# Altium schematic sheet encendido.SchDoc
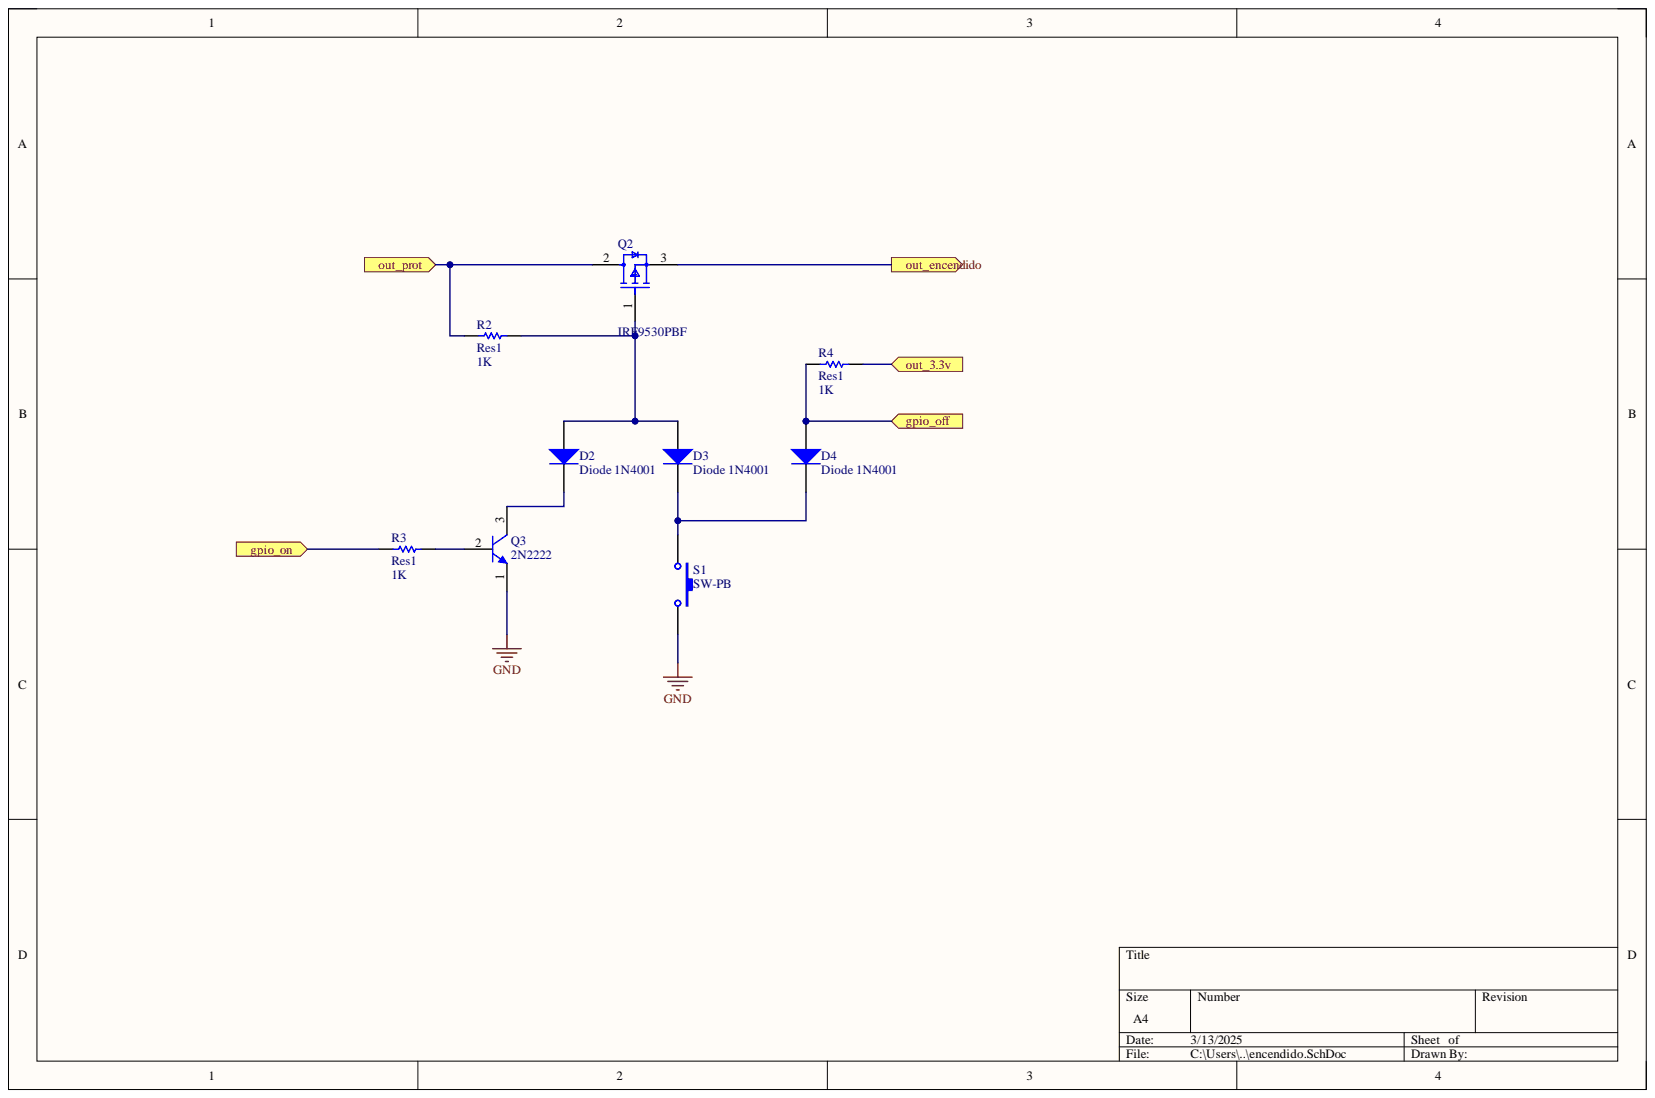
[diagram p0: encendido.SchDoc]

## Parts
D2  Diode 1N4001  [DO-41]
D3  Diode 1N4001  [DO-41]
D4  Diode 1N4001  [DO-41]
Q2  IRF9530PBF  [FP-X15-0364-MFG]
Q3  2N2222  [MLTC-TO-18-3]
R2  Res1  value 1K  [AXIAL-0.3]
R3  Res1  value 1K  [AXIAL-0.3]
R4  Res1  value 1K  [AXIAL-0.3]
S1  SW-PB  [SPST-2]

## Ports
gpio_off, gpio_on, out_3.3v, out_encendido, out_prot

## Nets
GND: Q3.1 S1.2
gpio_off: D4.1 R4.1 ; port gpio_off
gpio_on: R3.1 ; port gpio_on
out_3.3v: R4.2 ; port out_3.3v
out_encendido: Q2.3 ; port out_encendido
out_prot: Q2.2 R2.1 ; port out_prot
NetD2_1: D2.1 D3.1 Q2.1 R2.2
NetD2_2: D2.2 Q3.3
NetD3_2: D3.2 D4.2 S1.1
NetQ3_2: Q3.2 R3.2

## Library data of the parts
D2-D4 = Diode 1N4001: 1 Amp General Purpose Rectifier; Code_JEDEC: DO-204-AL; Component Kind: Standard; ComponentLink1Description: Manufacturer Link; ComponentLink1URL: http://www.fairchildsemi.com/; ComponentLink2Description: Datasheet; ComponentLink2URL: http://www.fairchildsemi.com/ds/1N%2F1N4001.pdf; DatasheetVersion: 1998; LatestRevisionDate: 17-Jul-2002; LatestRevisionNote: Re-released for DXP Platform.; Library Name: Miscellaneous Devices.IntLib; PackageDescription: Diode, Axial; Body 5.2 x 2.7 mm (LxDia. max), Lead Dia. 0.86 mm (max); PackageReference: DO-41; PackageVersion: Aug-1999; Published: 8-Jun-2000; Signal Integrity: 1N4001; Simulation: 1N4001; Publisher: Altium Limited
Q2 = CMP-02550-000233-1: MOSFET P-CH 100V 12A TO-220AB; Current Rating: -12A; Drain to Source Breakdown Voltage: -100V; Drain to Source Resistance: 300mR; Element Configuration: Single; Fall Time: 39ns; Gate to Source Voltage (Vgs): 20V; Input Capacitance: 860pF; Max Power Dissipation: 88W; Number of Channels: 1; Polarity: P-CHANNEL; Polarization: P; Recovery Time: 240ns; Rise Time: 52ns; Threshold Voltage: -4V; Turn-Off Delay Time: 31ns; Turn-On Delay Time: 12ns; Case/Package: TO-220AB; Component Kind: Standard; Continuous Drain Current (ID): 12A; Drain to Source Voltage (Vdss): 100V; Drain-source On Resistance-Max: 300mR; Height: 9.01mm; Lead Free: Lead Free; Length: 10.41mm; Library Name: Altium Content Vault; Max Operating Temperature: 175°C; Min Operating Temperature: -55°C; Mount: Through Hole; Number of Elements: 1; Number of Pins: 3; On-State Resistance: 300mR; Package Quantity: 50; Packaging: Rail/Tube; Power Dissipation: 88W; Radiation Hardening: No; Rds On Max: 300mR; RoHS Compliant: Yes; Series: -; Voltage Rating (DC): -100V; Weight: 0.211644oz; Width: 4.7mm
Q3 = CMP-2000-05237-1: Low Power Bipolar NPN Transistor, 30 V, -65 to 200 degC, 3-Pin TO-18, RoHS, Bulk; Manufacturer: Multicomp; Collector Emitter Voltage V(br)ceo: 30V; Collector Emitter Voltage Vces: 400mV; Continuous Collector Current Ic Max: 800mA; Current Ic @ Vce Sat: 150mA; DC Current Gain hFE: 100; Full Power Rating Temperature: 25degC; Gain Bandwidth ft Min: 250MHz; No. of Pins: 3; No. of Transistors: 1; Pin Configuration: a; Power Dissipation Pd: 500mW; SVHC: NoSVHC(19-Dec-2012); Voltage Vcbo: 60V; Component Kind: Standard; Current Ic Continuous a Max: 800mA; Current Ic hFE: 150mA; Datasheet URL: http://www.farnell.com/datasheets/1662272.pdf; Datasheet Version: Rev. 1.1, 05/2012; DC Collector Current: 800mA; Gain Bandwidth ft Typ: 250MHz; Hfe Min: 100; Library Name: Altium Content Vault; Manufacturer URL: http://uk.farnell.com/b/multicomp; Package / Case: TO-18; Package Description: 3-Pin Transistor Outline Package (TO-18), Dia 5.54 mm, Pitch Pitch 1.485mm; Package Reference: TO-18-3; Power Dissipation Ptot Max: 500mW; Transistor Case Style: TO-18; Transistor Polarity: NPN
R2-R4 = Res1: Resistor; Component Kind: Standard; LatestRevisionDate: 17-Jul-2002; LatestRevisionNote: Re-released for DXP Platform.; Library Name: Miscellaneous Devices.IntLib; PackageDescription: Axial Device, Thru-Hole; 2 Leads; 0.3 in Pin Spacing; PackageReference: AXIAL-0.3; Published: 8-Jun-2000; Signal Integrity: Res; Simulation: RESISTOR; Publisher: Altium Limited
S1 = SW-PB: Switch; Component Kind: Standard; LatestRevisionDate: 17-Jul-2002; LatestRevisionNote: Re-released for DXP Platform.; Library Name: Miscellaneous Devices.IntLib; PackageDescription: Switch; 2 Leads; PackageReference: SPST-2; Published: 24-Mar-1999; Publisher: Altium Limited
